# Altium schematic sheet Serial Monitor Connection Board Schematic.SchDoc("Serial Monitor Connection Board Schematic")
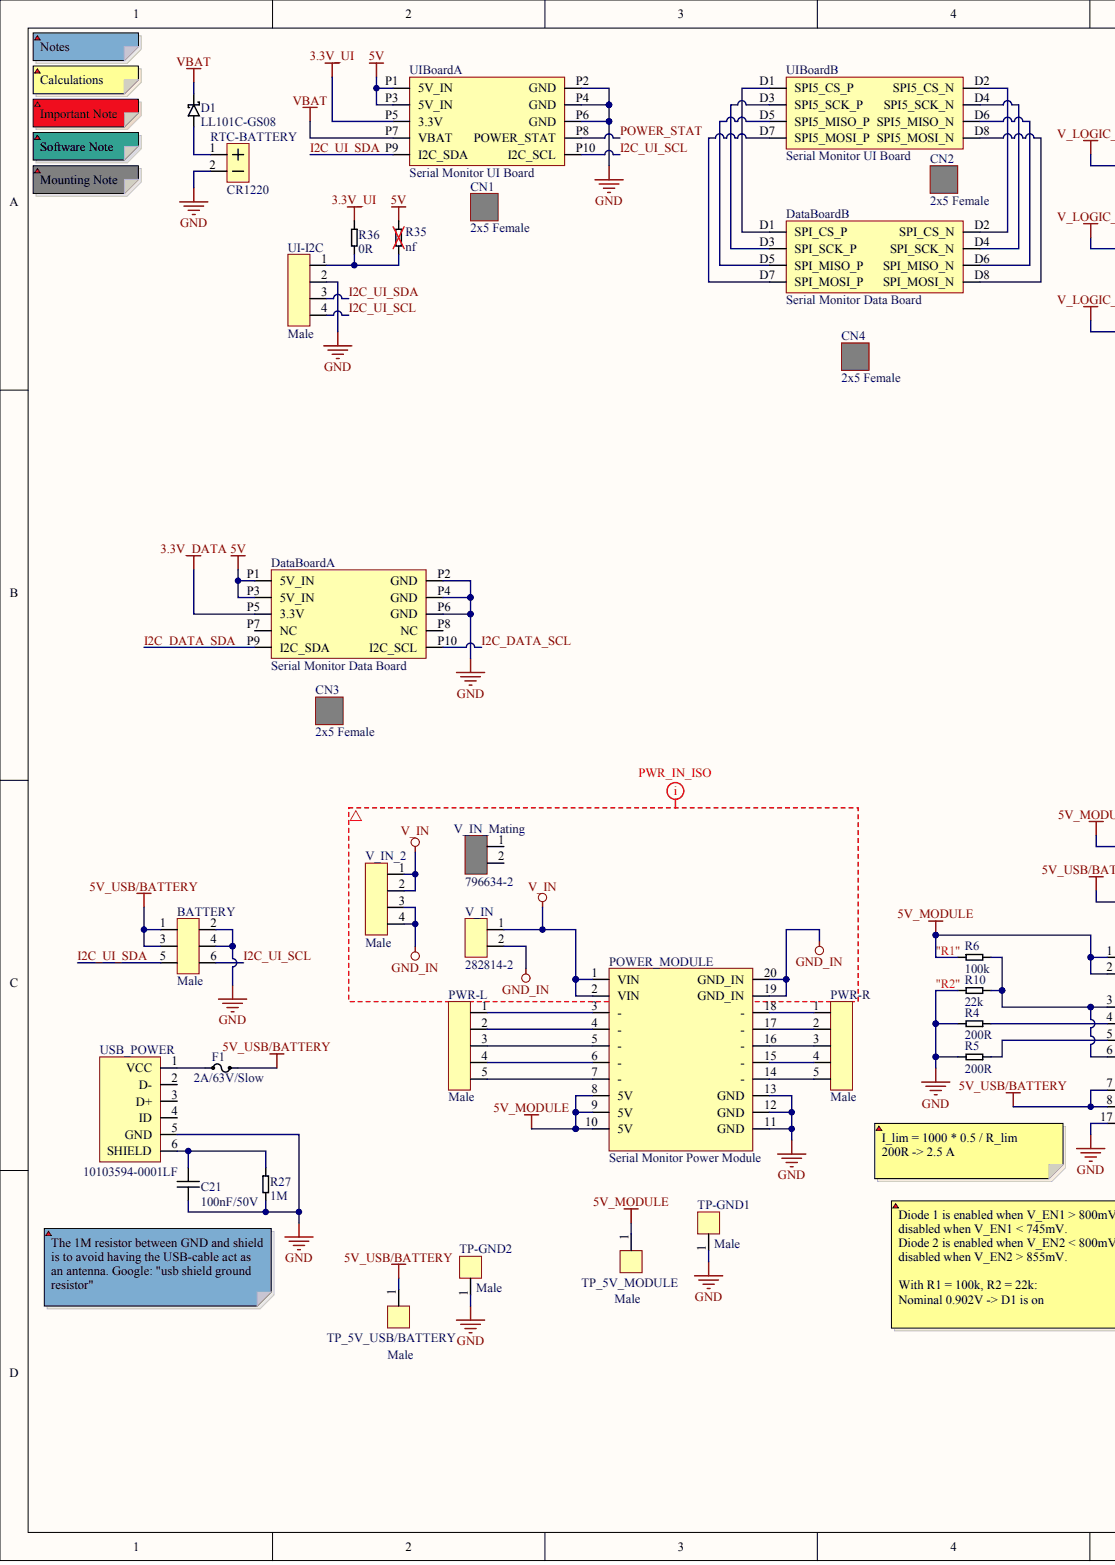
[diagram p0: Serial Monitor Connection Board Schematic.SchDoc, left]
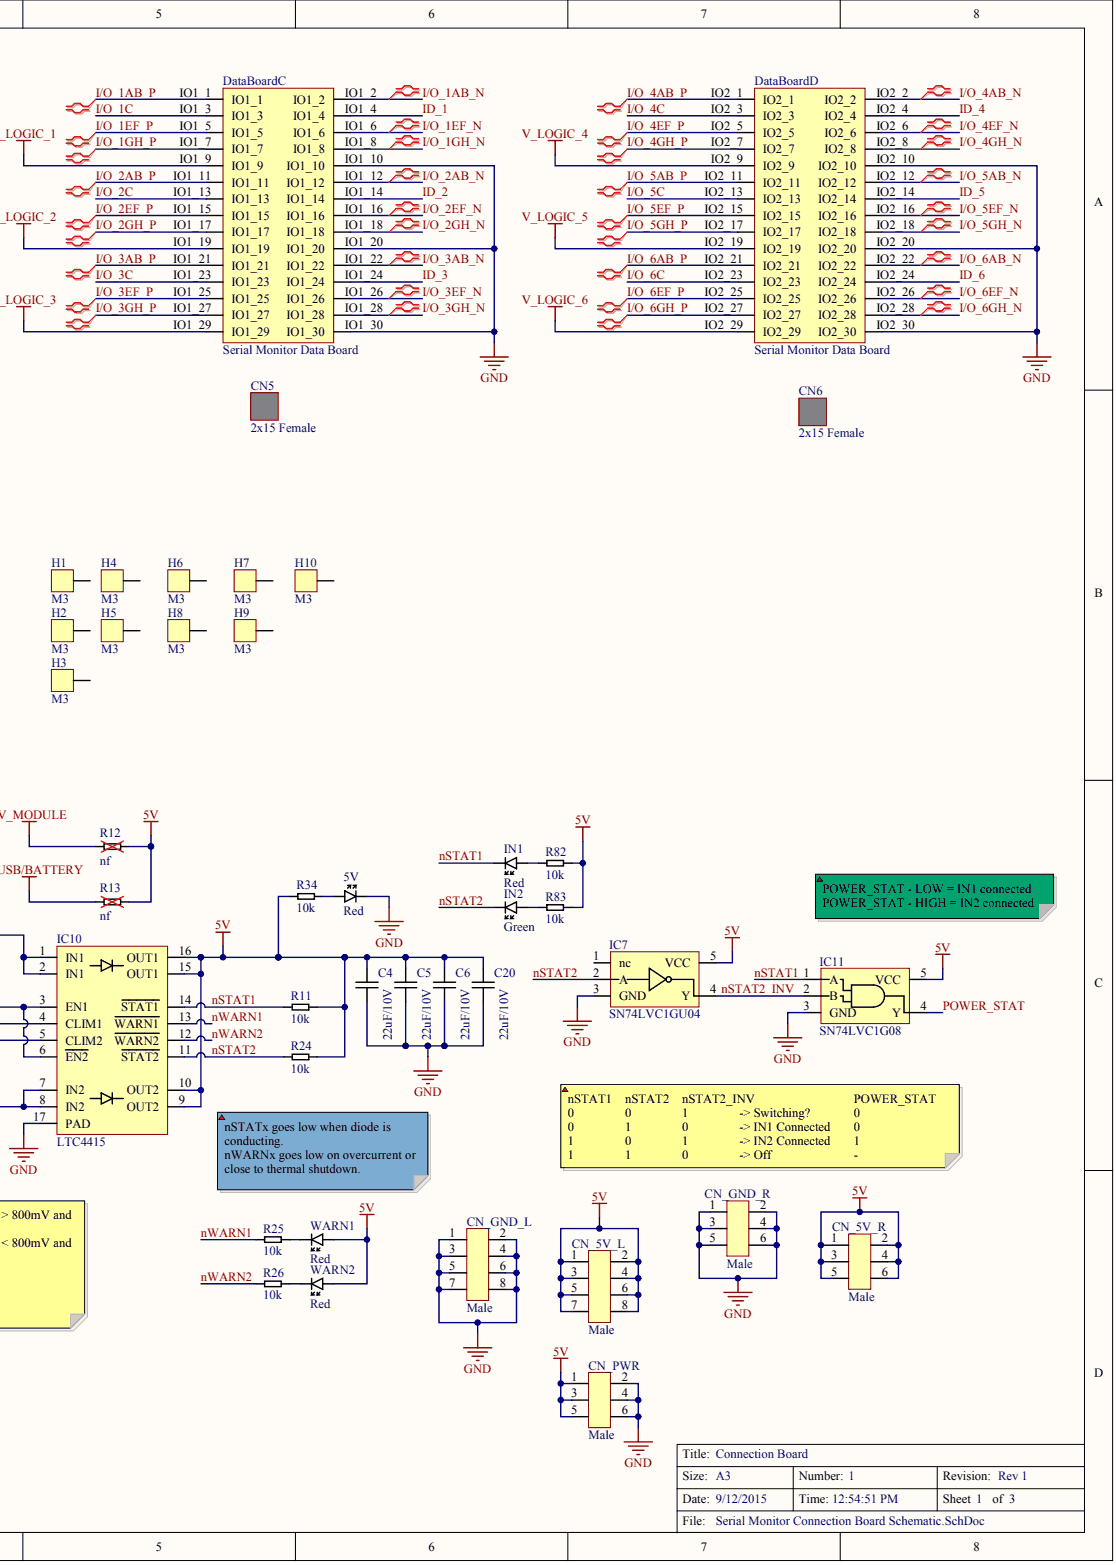
[diagram p1: Serial Monitor Connection Board Schematic.SchDoc, right]

## Parts
5V  value Red  (hidden comment: LED_0603_Red)
BATTERY  value Male  (hidden comment: Connector 2x3)
C4  value 22uF/10V  [0805]  (hidden comment: Cap_0805_22uF/10V)
C5  value 22uF/10V  [0805]  (hidden comment: Cap_0805_22uF/10V)
C6  value 22uF/10V  [0805]  (hidden comment: Cap_0805_22uF/10V)
C20  value 22uF/10V  [0805]  (hidden comment: Cap_0805_22uF/10V)
C21  value 100nF/50V  [0603]  (hidden comment: Cap_0603_100nF/50V)
CN1  value 2x5 Female  (hidden comment: Connector 2x5 Female Mechanical)
CN2  value 2x5 Female  (hidden comment: Connector 2x5 Female Mechanical)
CN3  value 2x5 Female  (hidden comment: Connector 2x5 Female Mechanical)
CN4  value 2x5 Female  (hidden comment: Connector 2x5 Female Mechanical)
CN5  value 2x15 Female  (hidden comment: Connector 2x15 Female Mechanical)
CN6  value 2x15 Female  (hidden comment: Connector 2x15 Female Mechanical)
CN_5V_L  value Male  (hidden comment: Connector 2x4)
CN_5V_R  value Male  (hidden comment: Connector 2x3)
CN_GND_L  value Male  (hidden comment: Connector 2x4)
CN_GND_R  value Male  (hidden comment: Connector 2x3)
CN_PWR  value Male  (hidden comment: Connector 2x3)
D1  LL101C-GS08
DataBoard  Serial Monitor Data Board  (parts A,B,C,D)
F1  value 2A/63V/Slow  [SMD 1206]  (hidden comment: Fuse_1206_2A_63V_Slow)
H1  value M3  (hidden comment: M3_SCREWHOLE)
H2  value M3  (hidden comment: M3_SCREWHOLE)
H3  value M3  (hidden comment: M3_SCREWHOLE)
H4  value M3  (hidden comment: M3_SCREWHOLE)
H5  value M3  (hidden comment: M3_SCREWHOLE)
H6  value M3  (hidden comment: M3_SCREWHOLE)
H7  value M3  (hidden comment: M3_SCREWHOLE)
H8  value M3  (hidden comment: M3_SCREWHOLE)
H9  value M3  (hidden comment: M3_SCREWHOLE)
H10  value M3  (hidden comment: M3_SCREWHOLE)
IC7  SN74LVC1GU04  [SOT-23-5]
IC10  LTC4415
IC11  SN74LVC1G08  [SOT-23-5]
IN1  value Red  (hidden comment: LED_0603_Red)
IN2  value Green  (hidden comment: LED_0603_Green)
POWER_MODULE  Serial Monitor Power Module
PWR-L  value Male  (hidden comment: Connector 1x5)
PWR-R  value Male  (hidden comment: Connector 1x5)
R4  value 200R  [0603]  (hidden comment: Res_0603_200R)
R5  value 200R  [0603]  (hidden comment: Res_0603_200R)
R6  value 100k  [0603]  (hidden comment: Res_0603_100k)
R10  value 22k  [0603]  (hidden comment: Res_0603_22k)
R11  value 10k  [0603]  (hidden comment: Res_0603_10k)
R12  value nf  [0805]  (hidden comment: Res_0805_nf)
R13  value nf  [0805]  (hidden comment: Res_0805_nf)
R24  value 10k  [0603]  (hidden comment: Res_0603_10k)
R25  value 10k  [0603]  (hidden comment: Res_0603_10k)
R26  value 10k  [0603]  (hidden comment: Res_0603_10k)
R27  value 1M  [0603]  (hidden comment: Res_0603_1M)
R34  value 10k  [0603]  (hidden comment: Res_0603_10k)
R35  value nf  [0603]  (hidden comment: Res_0603_nf)
R36  value 0R  [0603]  (hidden comment: Res_0603_0R)
R82  value 10k  [0603]  (hidden comment: Res_0603_10k)
R83  value 10k  [0603]  (hidden comment: Res_0603_10k)
RTC-BATTERY  value CR1220  (hidden comment: Coin Cell Holder CR1220)
TP-GND1  value Male  (hidden comment: Connector 1x1)
TP-GND2  value Male  (hidden comment: Connector 1x1)
TP_5V_MODULE  value Male  (hidden comment: Connector 1x1)
TP_5V_USB/BATTERY  value Male  (hidden comment: Connector 1x1)
UI-I2C  value Male  (hidden comment: Connector 1x4)
UIBoard  Serial Monitor UI Board  (parts A,B)
USB_POWER  value 10103594-0001LF  (hidden comment: USB Micro 10103594-0001LF)
V_IN  value 282814-2  Part No.: 282814-2  (hidden comment: Terminal Block 1x2 5.08mm)
V_IN_2  value Male  (hidden comment: Connector 1x4)
V_IN_Mating  value 796634-2  Part No.: 796634-2  (hidden comment: Terminal Block 1x2 5.08mm Mating Connector)
WARN1  value Red  (hidden comment: LED_0603_Red)
WARN2  value Red  (hidden comment: LED_0603_Red)

## Nets
3.3V_DATA: DataBoard.P5(3.3V)
3.3V_UI: R36.2 UIBoard.P5(3.3V)
5V: C4.2 C5.2 C6.2 C20.2 CN_5V_L.1 CN_5V_L.2 CN_5V_L.3 CN_5V_L.4 CN_5V_L.5 CN_5V_L.6 CN_5V_L.7 CN_5V_L.8 CN_5V_R.1 CN_5V_R.2 CN_5V_R.3 CN_5V_R.4 CN_5V_R.5 CN_5V_R.6 CN_PWR.1 CN_PWR.3 CN_PWR.5 DataBoard.P1(5V_IN) DataBoard.P3(5V_IN) IC7.5(VCC) IC10.9(OUT2) IC10.10(OUT2) IC10.15(OUT1) IC10.16(OUT1) IC11.5(VCC) R11.2 R12.2 R13.2 R24.2 R34.2 R35.2 R82.1 R83.1 UIBoard.P1(5V_IN) UIBoard.P3(5V_IN) WARN1.ANODE WARN2.ANODE
5V_MODULE: IC10.1(IN1) IC10.2(IN1) POWER_MODULE.8(5V) POWER_MODULE.9(5V) POWER_MODULE.10(5V) R6.2 R12.1 TP_5V_MODULE.1
5V_USB/BATTERY: BATTERY.1 BATTERY.3 F1.2 IC10.7(IN2) IC10.8(IN2) R13.1 TP_5V_USB/BATTERY.1
GND: 5V.CATHODE BATTERY.2 BATTERY.4 C4.1 C5.1 C6.1 C20.1 C21.1 CN_GND_L.1 CN_GND_L.2 CN_GND_L.3 CN_GND_L.4 CN_GND_L.5 CN_GND_L.6 CN_GND_L.7 CN_GND_L.8 CN_GND_R.1 CN_GND_R.2 CN_GND_R.3 CN_GND_R.4 CN_GND_R.5 CN_GND_R.6 CN_PWR.2 CN_PWR.4 CN_PWR.6 DataBoard.IO1_10 DataBoard.IO1_20 DataBoard.IO1_30 DataBoard.IO2_10 DataBoard.IO2_20 DataBoard.IO2_30 DataBoard.P2(GND) DataBoard.P4(GND) DataBoard.P6(GND) IC7.3(GND) IC10.17(PAD) IC11.3(GND) POWER_MODULE.11(GND) POWER_MODULE.12(GND) POWER_MODULE.13(GND) R4.1 R5.1 R10.2 R27.1 RTC-BATTERY.2 TP-GND1.1 TP-GND2.1 UI-I2C.2 UIBoard.P2(GND) UIBoard.P4(GND) UIBoard.P6(GND) USB_POWER.5(GND)
GND_IN: POWER_MODULE.19(GND_IN) POWER_MODULE.20(GND_IN) V_IN.2 V_IN_2.3 V_IN_2.4
V_IN: POWER_MODULE.1(VIN) POWER_MODULE.2(VIN) V_IN.1 V_IN_2.1 V_IN_2.2
V_LOGIC_1: DataBoard.IO1_9
V_LOGIC_2: DataBoard.IO1_19
V_LOGIC_3: DataBoard.IO1_29
V_LOGIC_4: DataBoard.IO2_9
V_LOGIC_5: DataBoard.IO2_19
V_LOGIC_6: DataBoard.IO2_29
VBAT: D1.1 UIBoard.P7(VBAT)
I2C_DATA_SCL: DataBoard.P10(I2C_SCL)
I2C_DATA_SDA: DataBoard.P9(I2C_SDA)
I2C_UI_SCL: BATTERY.6 UI-I2C.4 UIBoard.P10(I2C_SCL)
I2C_UI_SDA: BATTERY.5 UI-I2C.3 UIBoard.P9(I2C_SDA)
I/O_1AB_N: DataBoard.IO1_2
I/O_1AB_P: DataBoard.IO1_1
I/O_1C: DataBoard.IO1_3
I/O_1EF_N: DataBoard.IO1_6
I/O_1EF_P: DataBoard.IO1_5
I/O_1GH_N: DataBoard.IO1_8
I/O_1GH_P: DataBoard.IO1_7
I/O_2AB_N: DataBoard.IO1_12
I/O_2AB_P: DataBoard.IO1_11
I/O_2C: DataBoard.IO1_13
I/O_2EF_N: DataBoard.IO1_16
I/O_2EF_P: DataBoard.IO1_15
I/O_2GH_N: DataBoard.IO1_18
I/O_2GH_P: DataBoard.IO1_17
I/O_3AB_N: DataBoard.IO1_22
I/O_3AB_P: DataBoard.IO1_21
I/O_3C: DataBoard.IO1_23
I/O_3EF_N: DataBoard.IO1_26
I/O_3EF_P: DataBoard.IO1_25
I/O_3GH_N: DataBoard.IO1_28
I/O_3GH_P: DataBoard.IO1_27
I/O_4AB_N: DataBoard.IO2_2
I/O_4AB_P: DataBoard.IO2_1
I/O_4C: DataBoard.IO2_3
I/O_4EF_N: DataBoard.IO2_6
I/O_4EF_P: DataBoard.IO2_5
I/O_4GH_N: DataBoard.IO2_8
I/O_4GH_P: DataBoard.IO2_7
I/O_5AB_N: DataBoard.IO2_12
I/O_5AB_P: DataBoard.IO2_11
I/O_5C: DataBoard.IO2_13
I/O_5EF_N: DataBoard.IO2_16
I/O_5EF_P: DataBoard.IO2_15
I/O_5GH_N: DataBoard.IO2_18
I/O_5GH_P: DataBoard.IO2_17
I/O_6AB_N: DataBoard.IO2_22
I/O_6AB_P: DataBoard.IO2_21
I/O_6C: DataBoard.IO2_23
I/O_6EF_N: DataBoard.IO2_26
I/O_6EF_P: DataBoard.IO2_25
I/O_6GH_N: DataBoard.IO2_28
I/O_6GH_P: DataBoard.IO2_27
ID_1: DataBoard.IO1_4
ID_2: DataBoard.IO1_14
ID_3: DataBoard.IO1_24
ID_4: DataBoard.IO2_4
ID_5: DataBoard.IO2_14
ID_6: DataBoard.IO2_24
NSTAT1: IC10.14(STAT1) IC11.1(A) IN1.CATHODE R11.1
NSTAT2: IC7.2(A) IC10.11(STAT2) IN2.CATHODE R24.1
NSTAT2_INV: IC7.4(Y) IC11.2(B)
NWARN1: IC10.13(WARN1) R25.1
NWARN2: IC10.12(WARN2) R26.1
POWER_STAT: IC11.4(Y) UIBoard.P8(POWER_STAT)
Net5V_ANODE: 5V.ANODE R34.1
NetC21_2: C21.2 R27.2 USB_POWER.6(SHIELD)
NetD1_2: D1.2 RTC-BATTERY.1
NetDataBoard_D1: DataBoard.D1(SPI_CS_P) UIBoard.D1(SPI5_CS_P)
NetDataBoard_D2: DataBoard.D2(SPI_CS_N) UIBoard.D2(SPI5_CS_N)
NetDataBoard_D3: DataBoard.D3(SPI_SCK_P) UIBoard.D3(SPI5_SCK_P)
NetDataBoard_D4: DataBoard.D4(SPI_SCK_N) UIBoard.D4(SPI5_SCK_N)
NetDataBoard_D5: DataBoard.D5(SPI_MISO_P) UIBoard.D5(SPI5_MISO_P)
NetDataBoard_D6: DataBoard.D6(SPI_MISO_N) UIBoard.D6(SPI5_MISO_N)
NetDataBoard_D7: DataBoard.D7(SPI_MOSI_P) UIBoard.D7(SPI5_MOSI_P)
NetDataBoard_D8: DataBoard.D8(SPI_MOSI_N) UIBoard.D8(SPI5_MOSI_N)
NetF1_1: F1.1 USB_POWER.1(VCC)
NetIC10_3: IC10.3(EN1) IC10.6(EN2) R6.1 R10.1
NetIC10_4: IC10.4(CLIM1) R4.2
NetIC10_5: IC10.5(CLIM2) R5.2
NetIN1_ANODE: IN1.ANODE R82.2
NetIN2_ANODE: IN2.ANODE R83.2
NetPOWER_MODULE_3: POWER_MODULE.3(-) PWR-L.1
NetPOWER_MODULE_4: POWER_MODULE.4(-) PWR-L.2
NetPOWER_MODULE_5: POWER_MODULE.5(-) PWR-L.3
NetPOWER_MODULE_6: POWER_MODULE.6(-) PWR-L.4
NetPOWER_MODULE_7: POWER_MODULE.7(-) PWR-L.5
NetPOWER_MODULE_14: POWER_MODULE.14(-) PWR-R.5
NetPOWER_MODULE_15: POWER_MODULE.15(-) PWR-R.4
NetPOWER_MODULE_16: POWER_MODULE.16(-) PWR-R.3
NetPOWER_MODULE_17: POWER_MODULE.17(-) PWR-R.2
NetPOWER_MODULE_18: POWER_MODULE.18(-) PWR-R.1
NetR25_2: R25.2 WARN1.CATHODE
NetR26_2: R26.2 WARN2.CATHODE
NetR35_1: R35.1 R36.1 UI-I2C.1
N00339:

Not connected: DataBoard P7(NC) P8(NC); H1 HOLE; H2 HOLE; H3 HOLE; H4 HOLE; H5 HOLE; H6 HOLE; H7 HOLE; H8 HOLE; H9 HOLE; H10 HOLE; IC7 1(nc); USB_POWER 2(D-) 3(D+) 4(ID); V_IN_Mating 1 2

## Library data of the parts
5V IN1 WARN1 WARN2 = (no symbol name): Part No.: APT1608SRCPRV; Manufacturer: Kingbright; RS: 654-5420; DigiKey: 754-1122-1-ND; Price SEK (No VAT): 1; Mouser: 604-APT1608SRCPRV
C4-C6 C20 = (no symbol name): Part No.: C2012X5R1A226M125AB; Manufacturer: TDK; DigiKey: 445-7665-1-ND; Mouser: 810-C2012X5R1A226M-3; Price SEK (No VAT): 4
  C4: Value: 22uF/10V
C21 = (no symbol name): Part No.: C1608X7R1H104K080AA; Manufacturer: TDK; RS: 741-4459 (500-pack); DigiKey: 445-1314-1-ND; Mouser: 810-C1608X7R1H104K; Price SEK (No VAT): 0,2
D1 = (no symbol name): Manufacturer: Vishay; Part No.: LL101C-GS08; Mouser: 625-LL101C; Price SEK (No VAT): 1; DigiKey: LL101C-GS08CT-ND; Value: LL101C-GS08
F1 = (no symbol name): Part No.: SF-1206S200-2; Manufacturer: Bourns; RS: 692-5053 (10-pack); Mouser: 652-SF-1206S200-2; Price SEK (No VAT): 5; DigiKey: SF-1206S200-2CT-ND; Farnell: 1652161
IC7 = (no symbol name): Part No.: SN74LVC1GU04DBVR; Manufacturer: TI; DigiKey: 296-8487-1-ND; Price SEK (No VAT): 3; Mouser: 595-SN74LVC1GU04DBVR; Value: SN74LVC1GU04
IC10 = (no symbol name): Part No.: LTC4415EMSE; Manufacturer: Linear; RS: 822-9903; DigiKey: LTC4415EMSE#PBF-ND; Price SEK (No VAT): 60; Datasheet: http://www.linear.com/docs/40584; Mouser: *; Package: MSOP-16; Value: LTC4415
IC11 = (no symbol name): Part No.: SN74LVC1G08DBVR; Manufacturer: TI; DigiKey: 296-11601-1-ND; Price SEK (No VAT): 3; Mouser: 595-SN74LVC1G08DBVR; RS: *; Farnell: 1287593 (5-pack); Value: SN74LVC1G08
IN2 = (no symbol name): Part No.: APT1608CGCK; Manufacturer: Kingbright; Mouser: 604-APT1608CGCK; Price SEK (No VAT): 1; DigiKey: 754-1116-1-ND
R4 R5 = (no symbol name): Part No.: CRCW0603200RFKEA; Manufacturer: Vishay; Price SEK (No VAT): 0,1; Mouser: 71-CRCW0603-200-E3; DigiKey: 541-200HCT-ND
R6 = (no symbol name): Part No.: CRCW0603100KFKEA; Manufacturer: Vishayp; Price SEK (No VAT): 0,1; Mouser: 71-CRCW0603-100K-E3; DigiKey: 541-100KHCT-ND
R10 = (no symbol name): Part No.: CRCW060322K0FKEA; Manufacturer: Vishay; Price SEK (No VAT): 0,1; Mouser: 71-CRCW0603-22K-E3; DigiKey: 541-22.0KHCT-ND
R11 R24-R26 R34 R82 R83 = (no symbol name): Part No.: CRCW060310K0FKEA; Manufacturer: Vishay; Price SEK (No VAT): 0,1; Mouser: 71-CRCW0603-10K-E3; DigiKey: 541-10.0KHCT-ND
R27 = (no symbol name): Part No.: CRCW06031M00FKEA; Manufacturer: Vishay; Price SEK (No VAT): 0,1; DigiKey: 541-1.00MHCT-ND; Mouser: 71-CRCW0603-1.0M-E3
R36 = (no symbol name): Part No.: CRCW06030000Z0EA; Manufacturer: Vishay; Price SEK (No VAT): 0,1; DigiKey: 541-0.0GCT-ND; Mouser: 71-CRCW0603-0-E3
RTC-BATTERY = (no symbol name): Manufacturer: Harwin; Part No.: S8411-45R; Mouser: 855-S8411-45R; DigiKey: 952-1745-1-ND; Price SEK (No VAT): 8; Datasheet: http://www.harwin.com/include/downloads/drawings/S8411R.PDF; Farnell: 2115306
USB_POWER = (no symbol name): Manufacturer: FCI; RS: 714-2347; Mouser: 649-10103594-0001LF; DigiKey: 609-4050-1-ND; Farnell: 2293752; Price SEK (No VAT): 5; Value: 10103594-0001LF; Part No.: 10103594-0001LF
V_IN = (no symbol name): Manufacturer: TE Connectivity; Mating Connector: 796634-2; RS: 710-0393 (10-pack); Mouser: 571-282814-2; DigiKey: A98065-ND; Elfa: *; Price SEK (No VAT): 4
V_IN_Mating = (no symbol name): Manufacturer: TE Connectivity; RS: 361-7320 (5-pack); Mouser: 571-7966342; DigiKey: A98223-ND; Elfa: *; Price SEK (No VAT): 10; Farnell: *
BATTERY CN1-CN6 CN_5V_L CN_5V_R CN_GND_L CN_GND_R CN_PWR DataBoard H1-H10 POWER_MODULE PWR-L PWR-R R12 R13 R35 TP-GND1 TP-GND2 TP_5V_MODULE TP_5V_USB/BATTERY UI-I2C UIBoard V_IN_2 = (no symbol name)
  DataBoard: Value: Serial Monitor Data Board
  POWER_MODULE: Value: Serial Monitor Power Module
  R35: Price SEK (No VAT): 0
  UIBoard: Value: Serial Monitor UI Board
